# Altium schematic sheet airSensorSchematic.SchDoc
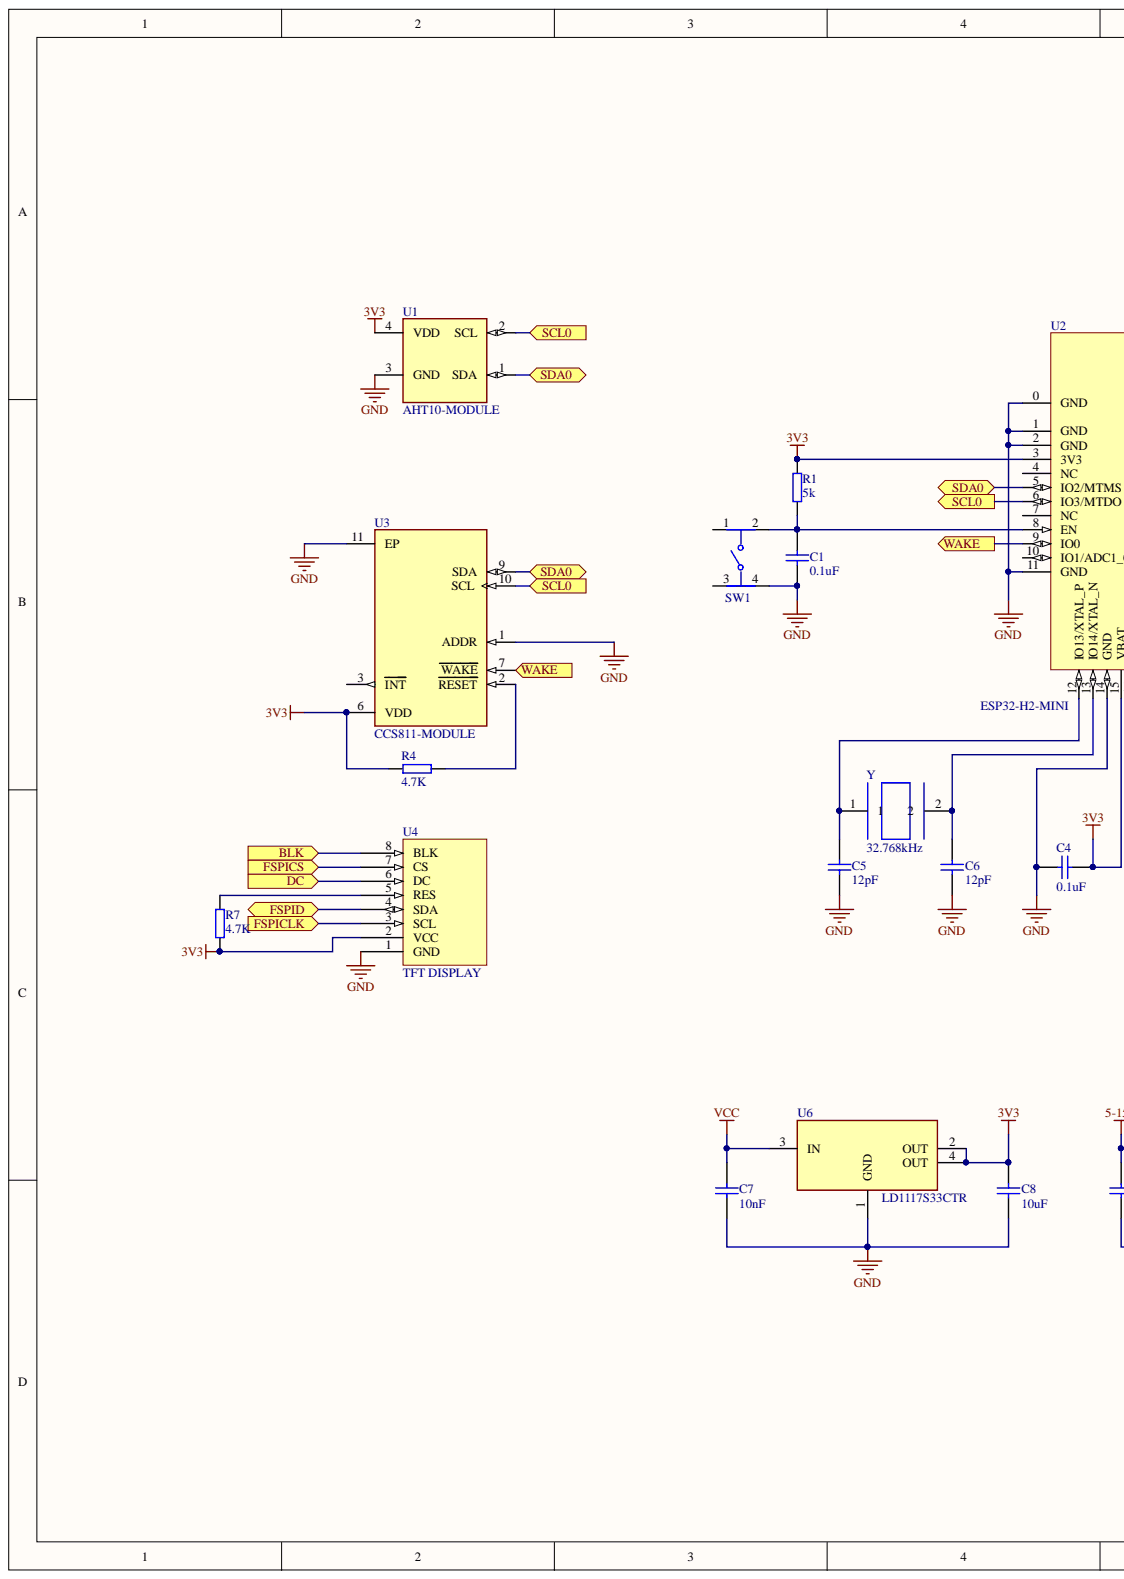
[diagram p0: airSensorSchematic.SchDoc, left]
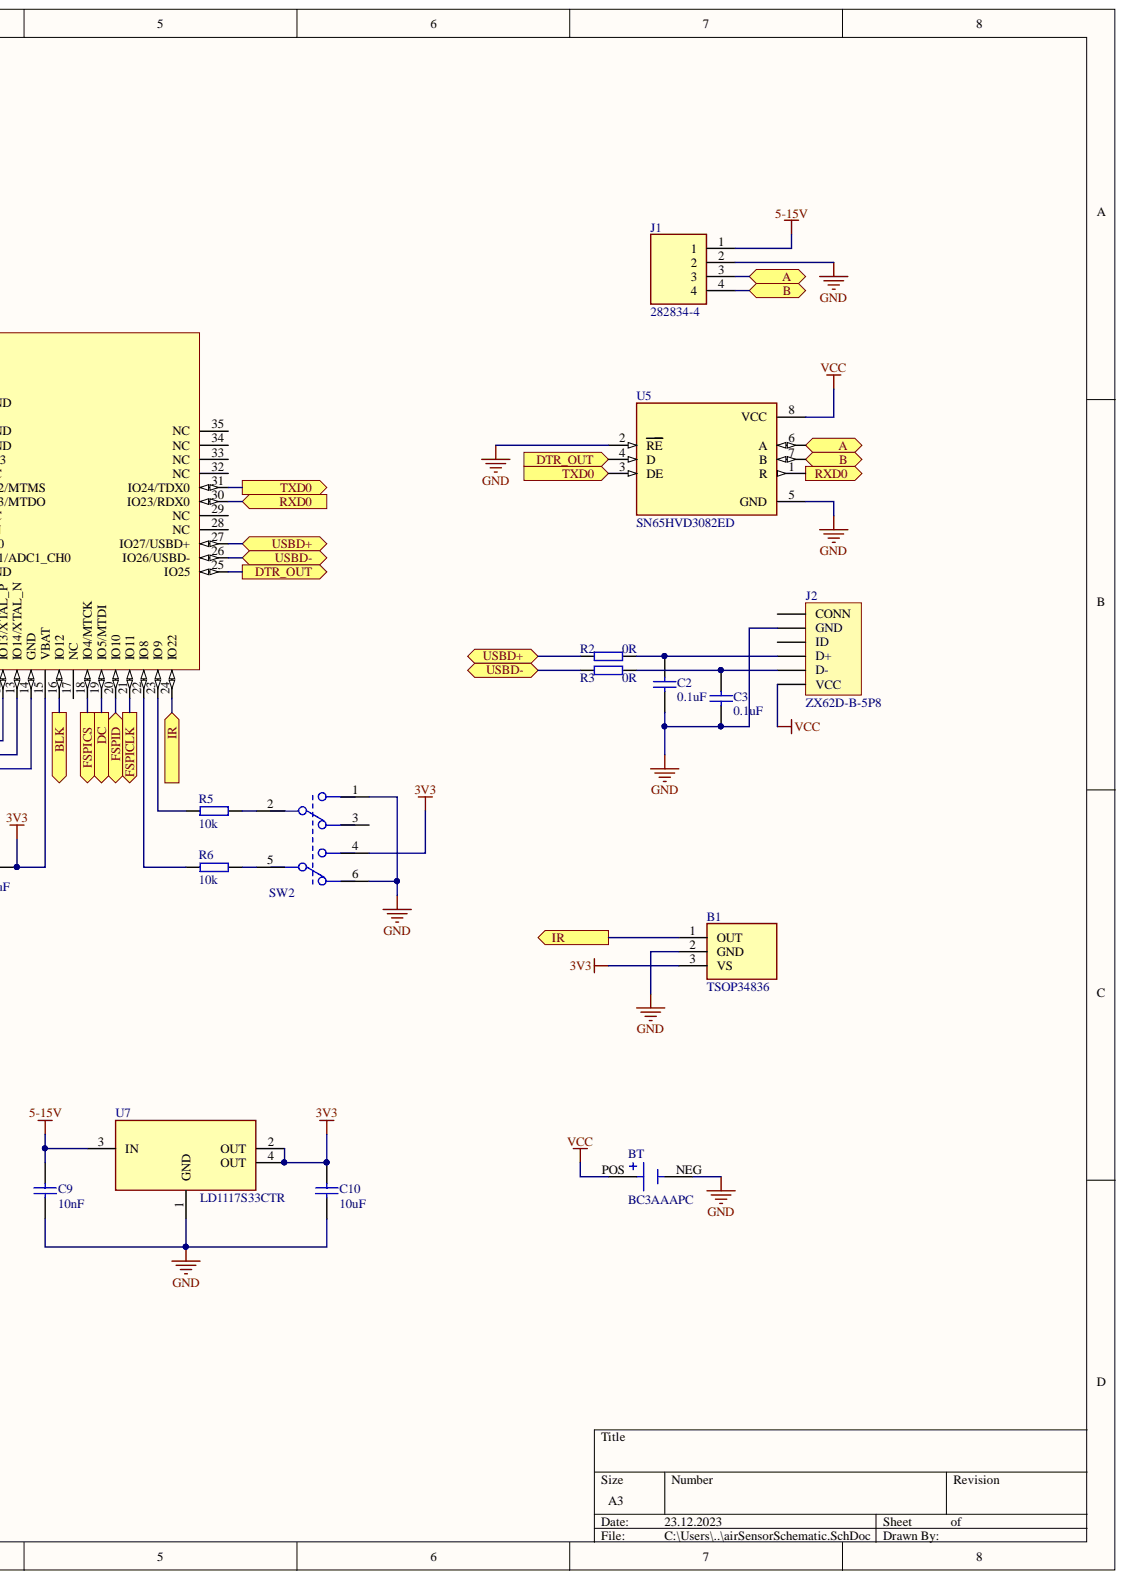
[diagram p1: airSensorSchematic.SchDoc, right]

## Parts
B1  TSOP34836  [TSOP34836]
BT  BC3AAAPC  [BAT_BC3AAAPC]
C1  value 0.1uF  [CAP0805]  (hidden comment: CAP)
C2  value 0.1uF  [CAP0805]  (hidden comment: CAP)
C3  value 0.1uF  [CAP0805]  (hidden comment: CAP)
C4  value 0.1uF  [CAP0805]  (hidden comment: CAP)
C5  value 12pF  [CAP0603]  (hidden comment: CAP)
C6  value 12pF  [CAP0603]  (hidden comment: CAP)
C7  value 10nF  [CAP0603]  (hidden comment: CAP)
C8  value 10uF  [CAP0603]  (hidden comment: CAP)
C9  value 10nF  [CAP0603]  (hidden comment: CAP)
C10  value 10uF  [CAP0603]  (hidden comment: CAP)
J1  282834-4  [TE_282834-4]
J2  ZX62D-B-5P8  [ZX62D-B-5P8]
R1  value 5k  [RES0805]  (hidden comment: RES)
R2  value 0R  [RES0805]  (hidden comment: RES)
R3  value 0R  [RES0805]  (hidden comment: RES)
R4  value 4.7K  [RES0603]  (hidden comment: RES)
R5  value 10k  [RES0805]  (hidden comment: RES)
R6  value 10k  [RES0805]  (hidden comment: RES)
R7  value 4.7K  [RES0603]  (hidden comment: RES)
SW1  PTS645SM43SMTR92LFS  [C&K_0_MFG]  (value not drawn)
SW2  JS202011SCQN  [401-2002-1-ND]  (value not drawn)
U1  AHT10-MODULE  [AHT10-MODULE]
U2  ESP32-H2-MINI  [ESP32-PICO-MINI-02]
U3  CCS811-MODULE  [CCS811-MODULE]
U4  TFT DISPLAY  [TFT DISPLAY MODULE]
U5  SN65HVD3082ED  [SOIC127P599X175-8N]
U6  LD1117S33CTR  [SOT223]
U7  LD1117S33CTR  [SOT223]
Y  32.768kHz  [XTAL320X150X90]

## Ports
A, B, BLK, DC, DTR_OUT, FSPICLK, FSPICS, FSPID, IR, RXD0, SCL0, SDA0, TXD0, USBD+, USBD-, WAKE

## Nets
3V3: B1.3 C4.2 C8.2 C10.2 R1.2 R4.1 R7.1 SW2.4 U1.4(VDD) U2.3(3V3) U2.15(VBAT) U3.6(VDD) U4.2(VCC) U6.2(OUT) U6.4(OUT) U7.2(OUT) U7.4(OUT)
5-15V: C9.2 J1.1 U7.3(IN)
GND: B1.2 BT.NEG C1.1 C2.1 C3.1 C4.1 C5.1 C6.1 C7.1 C8.1 C9.1 C10.1 J1.2 J2.5(GND) SW1.4 SW2.1 SW2.6 U1.3(GND) U2.0(GND) U2.1(GND) U2.2(GND) U2.11(GND) U2.14(GND) U3.1(ADDR) U3.11(EP) U4.1(GND) U5.2(RE) U5.5(GND) U6.1(GND) U7.1(GND)
VCC: BT.POS C7.2 J2.1(VCC) U5.8(VCC) U6.3(IN)
A: J1.3 U5.6(A) ; port A
B: J1.4 U5.7(B) ; port B
BLK: U2.16(IO12) U4.8(BLK) ; port BLK
DC: U2.19(IO5/MTDI) U4.6(DC) ; port DC
DTR_OUT: U2.25(IO25) U5.4(D) ; port DTR_OUT
FSPICLK: U2.21(IO11) U4.3(SCL) ; port FSPICLK
FSPICS: U2.18(IO4/MTCK) U4.7(CS) ; port FSPICS
FSPID: U2.20(IO10) U4.4(SDA) ; port FSPID
IR: B1.1 U2.24(IO22) ; port IR
RXD0: U2.30(IO23/RDX0) U5.1(R) ; port RXD0
SCL0: U1.2(SCL) U2.6(IO3/MTDO) U3.10(SCL) ; port SCL0
SDA0: U1.1(SDA) U2.5(IO2/MTMS) U3.9(SDA) ; port SDA0
TXD0: U2.31(IO24/TDX0) U5.3(DE) ; port TXD0
WAKE: U2.9(IO0) U3.7(WAKE) ; port WAKE
NetC1_2: C1.2 R1.1 SW1.2 U2.8(EN)
NetC2_2: C2.2 J2.3(D+) R2.2
NetC3_2: C3.2 J2.2(D-) R3.2
NetC5_2: C5.2 U2.12(IO13/XTAL_P) Y.1
NetC6_2: C6.2 U2.13(IO14/XTAL_N) Y.2
NetR2_1: R2.1 U2.27(IO27/USBD+)
NetR3_1: R3.1 U2.26(IO26/USBD-)
NetR4_2: R4.2 U3.2(RESET)
NetR5_1: R5.1 U2.23(IO9)
NetR5_2: R5.2 SW2.2
NetR6_1: R6.1 U2.22(IO8)
NetR6_2: R6.2 SW2.5
NetR7_2: R7.2 U4.5(RES)

Not connected: J2 0(CONN) 4(ID); SW1 1 3; SW2 3; U2 4(NC) 7(NC) 10(IO1/ADC1_CH0) 17(NC) 28(NC) 29(NC) 32(NC) 33(NC) 34(NC) 35(NC); U3 3(INT)

## Library data of the parts
B1 = TSOP34836: Remote Receiver Sensor 36.0kHz 45m Through Hole; Component Kind: Standard; Library Name: SFH_4555.IntLib
BT = BC3AAAPC: BATTERY HOLDER AAA 3 CELL PC PIN; MF: MPD; Availability: Unavailable; Component Kind: Standard; Library Name: BC3AAAPC.IntLib; MP: BC3AAAPC; Package: None; Price: None; SnapEDA_Link: https://www.snapeda.com/parts/BC3AAAPC/MPD/view-part/4389109/?ref=snap
C1-C4 = CAP-SM-0805-X5R-1uF: CAP SM 0805 X5R 1uF; Manufacturer: GENERIC; Database Table Name: capacitors$; Component Kind: Standard; Library Name: electronpowered.dblib; Package: 0805; Part Number: CAP-SM-0805-X5R-1uF
C5 C6 = CAP-SM-0603-NP0-12pF: CAP SM 0603 NP0 12pF; Manufacturer: GENERIC; Database Table Name: capacitors$; Component Kind: Standard; Library Name: electronpowered.dblib; Package: 0603; Part Number: CAP-SM-0603-NP0-12pF
C7 C9 = CAP-SM-0603-X7R-10nF: CAP SM 0603 X7R 10nF; Manufacturer: GENERIC; Database Table Name: capacitors$; Component Kind: Standard; Library Name: electronpowered.dblib; Package: 0603; Part Number: CAP-SM-0603-X7R-10nF
C8 C10 = CAP-SM-0603-X5R-10uF: CAP SM 0603 X5R 10uF; Manufacturer: GENERIC; Database Table Name: capacitors$; Component Kind: Standard; Library Name: electronpowered.dblib; Package: 0603; Part Number: CAP-SM-0603-X5R-10uF
J1 = 282834-4: 4 Position Wire to Board Terminal Block Horizontal with Board 0.100 (2.54mm) Through Hole; MF: TE Connectivity; Availability: In Stock; Check_prices: https://www.snapeda.com/parts/282834-4/TE+Connectivity+AMP+Connectors/view-part/?ref=eda; Component Kind: Standard; EU_RoHS_Compliance: Compliant with Exemptions; Library Name: Terminal Block 4 POS 2.54mm.IntLib; MP: 282834-4; Package: None; Price: None; Purchase-URL: https://pricing.snapeda.com/search/part/282834-4/?ref=eda; SnapEDA_Link: https://www.snapeda.com/parts/282834-4/TE+Connectivity+AMP+Connectors/view-part/?ref=snap
J2 = ZX62D-B-5P8: CONN RCPT MICRO USB R/A SMD; Manufacturer: HIROSE; Database Table Name: connectors$; Component Kind: Standard; Library Name: electronpowered.dblib; Part Number: ZX62D-B-5P8; Value: ZX62D-B-5P8
R1-R3 R5 R6 = RES-SM-0805-0R: RES SM 0805 0R; Manufacturer: GENERIC; Database Table Name: resistors$; Component Kind: Standard; Library Name: electronpowered.dblib; Package: 0805; Part Number: RES-SM-0805-0R
R4 R7 = RES-SM-0603-4.7K: RES SM 0603 4.7K; Manufacturer: GENERIC; Database Table Name: resistors$; Component Kind: Standard; Library Name: electronpowered.dblib; Package: 0603; Part Number: RES-SM-0603-4.7K
SW1 = PTS645SM43SMTR92LFS: 6 mm Tact Switches; Manufacturer: C&K; Contact Current Rating: 50mA; Contact Resistance: 100mΩ; Database Table Name: Components$; Electromechanical Life: 100000Cycles; Operating Force: 160gf; Symbol Hash: 1adf0dd01260c80cf25a44bfd6b99ba959f28c458539ebeb6cf85a1c51f4466c; Throw Configuration: SPST-NO; Verification Version: 0.0.0.3; Voltage Rating Dc: 12V; Automotive: No; Component Kind: Standard; ComponentLink1Description: Upverter; ComponentLink1URL: https://upverter.com/part/id/a07da9863d53e461/; ComponentLink2Description: Datasheet; ComponentLink2URL: https://www.ckswitches.com/media/1471/pts645.pdf; Datasheet Path: datasheets/Switch_C&K_PTS645SM43SMTR92LFS_eec.pdf; Digikey Description: SWITCH TACTILE SPST-NO 0.05A 12V; Digikey Part Number: CKN9112CT-ND; Height: 4.4mm; helpURL: https://upverter.com/part/id/a07da9863d53e461/; Lead Free: Yes; Mount: Surface Mount; Mouser Description: SWITCH TACTILE SPST-NO 0.05A 12V; Mouser Part Number: 611-PTS645SM43SMTR92; Package: 0; Part Number: PTS645SM43SMTR92LFS; RoHS: TRUE; Supplier 1: Digi-Key; Supplier 2: Mouser; Supplier Part Number 1: CKN9112CT-ND; Supplier Part Number 2: 611-PTS645SM43SMTR92; Temperature: -20°C to +85°C; Temperature Range High: +85°C; Temperature Range Low: -20°C; Library Name: EEConcierge.DbLib
SW2 = JS Series DPDT On-On Gull Wing Surface Mount Subminiature Slide Switch: https://www.ckswitches.com/media/1422/js.pdf; Manufacturer: C&K_Components_0_MFG; Contact Resistance: Datasheet; Database Table Name: Components$; Electromechanical Life: Slide Switch DPDT Surface Mount; F39: DPDT; F40: 0.0.0.3; F41: 6V; Symbol Hash: Digi-Key; Throw Configuration: ac99ea1af431c19115f5225061c5c88f95af2a7ba6b3c4f58608c3959a9d85e3; Verification Version: -40°C to +85°C; Voltage Rating Dc: +85°C; Automotive: No; Component Kind: Standard; ComponentLink1Description: Switches; ComponentLink1URL: Industrial Control; ComponentLink2Description: Slide; ComponentLink2URL: Upverter; Contact Current Rating: https://upverter.com/part/id/5714d5fa193fe7b3/; Datasheet Path: datasheets/Switch_C&K_Components_JS202011SCQN_eec.pdf; Digikey Description: 0.3A; Digikey Part Number: 70mΩ; F42: 6V; F43: https://upverter.com/part/id/5714d5fa193fe7b3/; Height: 5000Cycles; helpURL: -40°C; Lead Free: parts/Switch_C&K_Components_JS202011SCQN_eec_MFG.PcbLib; Mount: 5.5mm; Mouser Description: Yes; Mouser Part Number: C&K Components; Operating Force: Surface Mount; Package: Surface Mount; Part Number: JS Series DPDT On-On Gull Wing Surface Mount Subminiature Slide Switch; RoHS: 611-JS202011SCQN; Supplier 1: 94a55dc393914b62; Supplier 2: 0; Supplier Part Number 1: JS202011SCQN; Supplier Part Number 2: TRUE; Temperature: Mouser; Temperature Range High: 401-2002-1-ND; Temperature Range Low: 611-JS202011SCQN; Library Name: EEConcierge.DbLib
U4 = TFT DISPLAY: 0.96"80x160 RGB; Component Kind: Standard; Library Name: TFT 80x160RGB.SchLib
U5 = SN65HVD3082ED: Half-duplex RS-485 transceiver 8-SOIC -40 to 85; MF: Texas Instruments; Availability: Good; Component Kind: Standard; DigiKey-Purchase-URL: https://snapeda.com/shop?store=DigiKey&id=306144; Library Name: SN65HVD3082ED.IntLib; Mouser-Purchase-URL: https://snapeda.com/shop?store=Mouser&id=306144; MP: SN65HVD3082ED; Package: SOIC-8 Texas Instruments; Price: None; SnapEDA_Link: https://www.snapeda.com/parts/SN65HVD3082ED/Texas%20Instruments/view-part/306144/?ref=snap; Texas_Instruments-Purchase-URL: https://snapeda.com/shop?store=Texas+Instruments&id=306144
U6 U7 = LD1117S33CTR: Linear Voltage Regulator IC Positive Fixed 1 Output 800mA SOT-223; MF: STMicroelectronics; Availability: In Stock; Check_prices: https://www.snapeda.com/parts/LD1117S33CTR/STMicroelectronics/view-part/?ref=eda; Component Kind: Standard; Library Name: LD1117S33CTR.IntLib; MP: LD1117S33CTR; Package: SOT-223 STMicroelectronics; Price: None; Purchase-URL: https://pricing.snapeda.com/search/part/LD1117S33CTR/?ref=eda; SnapEDA_Link: https://www.snapeda.com/parts/LD1117S33CTR/STMicroelectronics/view-part/?ref=snap
Y = ABS07-LR-32.768KHZ-6-T: 32.768kHz Â±20ppm Crystal 6pF 50 kOhms 2-SMD, No Lead; MF: Abracon; Availability: Unavailable; Component Kind: Standard; Library Name: XTAL-ABS07-LR-32.768KHZ-6-T.IntLib; MP: ABS07-LR-32.768KHZ-6-T; Package: SMD-2 Abracon; Price: None; SnapEDA_Link: https://www.snapeda.com/parts/ABS07-LR-32.768KHZ-6-T/Abracon%20LLC/view-part/523883/?ref=snap
U1 = AHT10-MODULE: Component Kind: Standard; Library Name: AHT10.IntLib
U2 = ESP32-H2-MINI: Component Kind: Standard; ComponentLink1Description: Datasheet; ComponentLink1URL: https://www.espressif.com/sites/default/files/documentation/esp32-h2-mini-1_mini-1u_datasheet_en.pdf; Library Name: ESP32.SchLib
U3 = CCS811-MODULE: Component Kind: Standard; Library Name: CCS811B.IntLib
